# Altium schematic sheet Overcurrent.SchDoc
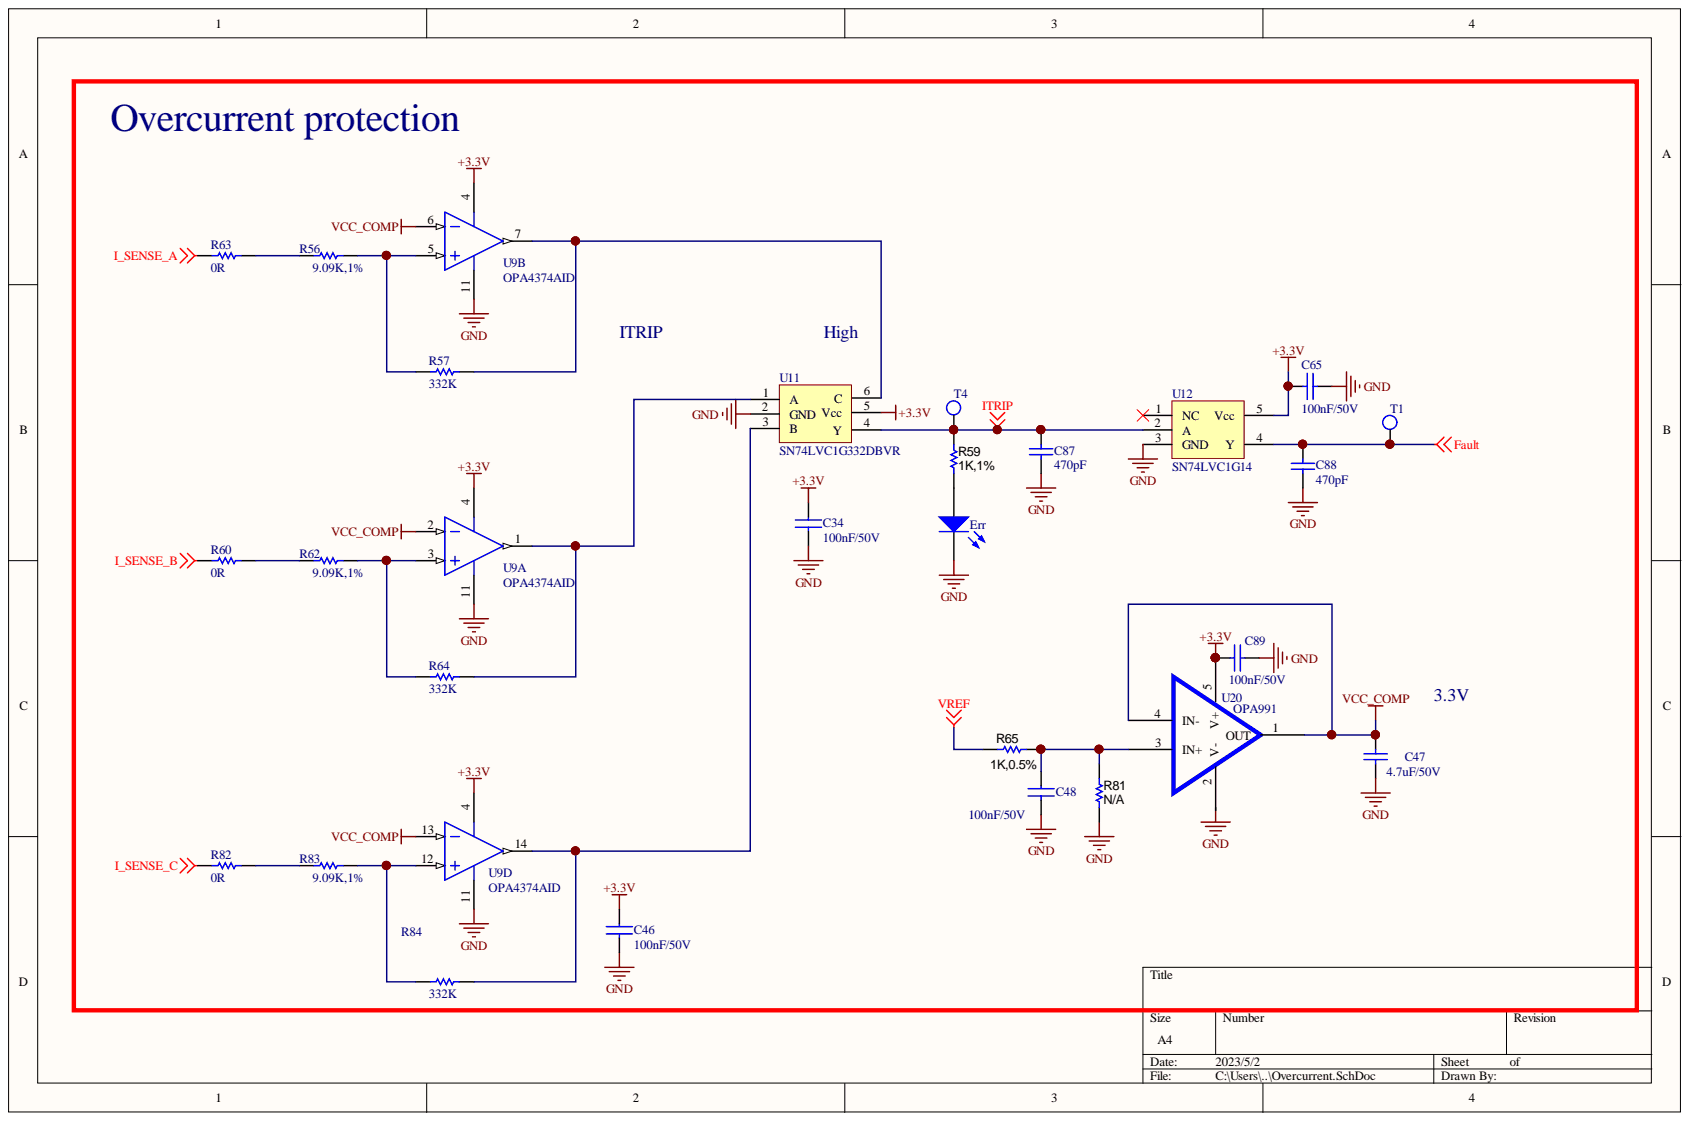
[diagram p0: Overcurrent.SchDoc]

## Parts
C34  100nF/50V  [0603]
C46  100nF/50V  [0603]
C47  4.7uF/50V  [1206]
C48  100nF/50V  [0603]
C65  100nF/50V  [0603]
C87  470pF  [0603]
C88  470pF  [0603]
C89  100nF/50V  [0603]
Err  RED  [0805_DIODE]  (value not drawn)
R56  9.09K,1%  [0603]
R57  332K  [0603]
R59  1K,1%  [0603]
R60  0R  [0603]
R62  9.09K,1%  [0603]
R63  0R  [0603]
R64  332K  [0603]
R65  1K,0.5%  [0603]
R81  N/A  [0603]
R82  0R  [0603]
R83  9.09K,1%  [0603]
R84  332K  [0603]
T1  Test_Ring  [1]  (value not drawn)
T4  Test_Ring  [1]  (value not drawn)
U9  OPA4374AID  [SOIC14_3.90MM]  (parts A,B,D)
U11  SN74LVC1G332DBVR  [SOT-236]
U12  SN74LVC1G14  [SOT-235]
U20  OPA991  [SOT-235]

## Nets
+3.3V: C34.1 C46.1 C65.2 C89.2 U9.4 U11.5(Vcc) U12.5(Vcc) U20.5(V+)
Fault: C88.1 T1.1 U12.4(Y)
GND: C34.2 C46.2 C47.1 C48.2 C65.1 C87.2 C88.2 C89.1 Err.2 R81.1 U9.11 U11.2(GND) U12.3(GND)
I_SENSE_A: R63.1
I_SENSE_B: R60.1
I_SENSE_C: R82.1
ITRIP: C87.1 R59.2 T4.1 U11.4(Y) U12.2(A)
VCC_COMP: C47.2 U9.2 U9.6 U9.13 U20.1(OUT) U20.4(IN-)
VREF: R65.1
NetC48_1: C48.1 R65.2 R81.2 U20.3(IN+)
NetErr_1: Err.1 R59.1
NetR56_1: R56.1 R63.2
NetR56_2: R56.2 R57.1 U9.5
NetR57_2: R57.2 U9.7 U11.6(C)
NetR60_2: R60.2 R62.1
NetR62_2: R62.2 R64.1 U9.3
NetR64_2: R64.2 U9.1 U11.1(A)
NetR82_2: R82.2 R83.1
NetR83_2: R83.2 R84.1 U9.12
NetR84_2: R84.2 U9.14 U11.3(B)

Not connected: U12 1(NC); U20 2(V-)

## Library data of the parts
C34 C46 C48 = Component-CAP: User Parameter 1: foot; Component Kind: Standard; Library Name: AltiumDesigner_Lib-tony.SchLib
C47 C87 C88 = C1206: Component Kind: Standard; Library Name: useForA104.IntLib
C65 C89 = CAP: Capacitor; Component Kind: Standard
Err = LED 0805: Component Kind: Standard; Library Name: useForA104.IntLib
R56 R57 R60 R62-R64 R82-R84 = R1206: Component Kind: Standard; Library Name: useForA104.IntLib
R59 = RC0603FR-071KL: Manufacturer: Yageo America; CompType: Resistor; EIA_Code: E96; Power_W: 0.1; Res_ohm: 1000; TempCo: 200 ppm/C; Tolerance_%: 1; U/M: EA; Value: 1.00k; Value2: 0.1W; Value_Code: 1k00; VDC_V: 150; Component Kind: Standard; ComponentLink1Description: Datasheet link; ComponentLink1URL: http://www.yageo.com/pdf/Pu-RC0603_51_PbFree_L_2.pdf; LatestRevisionDate: 2014-05-20; Library Name: Resistor_0603.IntLib; MountType: SMT; PackageReference: 0603; PartNumber: RC0603FR-071KL; Published: 2008-06-26; RoHS: Y; Signal Integrity: Resistor; Simulation: Resistor; Supplier 1: Digi-Key; Supplier 2: Mouser; Supplier 3: Farnell; Supplier Part Number 1: 311-1.00KHRCT-ND; Supplier Part Number 2: 603-RC0603FR-071KL; Supplier Part Number 3: 9238484; SymbolVersion: 2009-05-29; Technology: Thick Film; Value1: 1%; Publisher: Texas Instruments
R65 = RC0603FR-071KL: RES, 1.00k ohm, 1%, 0.1W, 0603; Manufacturer: Yageo America; CompType: Resistor; EIA_Code: E96; Power_W: 0.1; Res_ohm: 1000; TempCo: 200 ppm/C; Tolerance_%: 1; U/M: EA; Value_Code: 1k00; VDC_V: 150; Component Kind: Standard; ComponentLink1Description: Datasheet link; ComponentLink1URL: http://www.yageo.com/pdf/Pu-RC0603_51_PbFree_L_2.pdf; LatestRevisionDate: 2014-05-20; Library Name: Resistor_0603.IntLib; MountType: SMT; PackageReference: 0603; PartNumber: RC0603FR-071KL; Published: 2008-06-26; RoHS: Y; Signal Integrity: Resistor; Simulation: Resistor; Supplier 1: Digi-Key; Supplier 2: Mouser; Supplier 3: Farnell; Supplier Part Number 1: 311-1.00KHRCT-ND; Supplier Part Number 2: 603-RC0603FR-071KL; Supplier Part Number 3: 9238484; SymbolVersion: 2009-05-29; Technology: Thick Film; Value: 1.00k; Value1: 1%; Value2: 0.1W; Publisher: Texas Instruments
R81 = RC0603FR-071KL: Manufacturer: Yageo America; CompType: Resistor; EIA_Code: E96; Power_W: 0.1; Res_ohm: 1000; TempCo: 200 ppm/C; Tolerance_%: 1; U/M: EA; Value: 1.00k; Value1: 1%; Value2: 0.1W; Value_Code: 1k00; VDC_V: 150; Component Kind: Standard; ComponentLink1Description: Datasheet link; ComponentLink1URL: http://www.yageo.com/pdf/Pu-RC0603_51_PbFree_L_2.pdf; LatestRevisionDate: 2014-05-20; Library Name: Resistor_0603.IntLib; MountType: SMT; PackageReference: 0603; PartNumber: RC0603FR-071KL; Published: 2008-06-26; RoHS: Y; Signal Integrity: Resistor; Simulation: Resistor; Supplier 1: Digi-Key; Supplier 2: Mouser; Supplier 3: Farnell; Supplier Part Number 1: 311-1.00KHRCT-ND; Supplier Part Number 2: 603-RC0603FR-071KL; Supplier Part Number 3: 9238484; SymbolVersion: 2009-05-29; Technology: Thick Film; Publisher: Texas Instruments
U9 = OPA4374AIPWT: Quad 6.5 MHz, 585 uA, Rail-to-Rail I/O CMOS Operational Amplifier, 2.3 to 5.5 V, -40 to 125 degC, 14-pin SOP (PW14), Green (RoHS & no Sb/Br); Manufacturer: Texas Instruments; CMRR(Min)(dB): 80; GBW(Typ)(MHz): 6.5; IIB(Max)(pA): 10; Iq per channel(Max)(mA): 0.75; Number of Channels: 4; Offset Drift(Typ)(uV/C): 3; Packing: SMALL T&R; Rating: Catalog; Slew Rate(Typ)(V/us): 5; Sub Family: High Output Current Amplifier (>=50mA), Low Input Bias Current Amp (<=10pA), Low Supply Voltage Amplifier (<=2.7V), Precision Amplifier; Total Supply Voltage(Max)(+5V is 5, +/-5V is 10): 5.5; Total Supply Voltage(Min)(+5V is 5, +/-5V is 10): 2.3; Code_JEDEC: MO-153; Component Kind: Standard; ComponentLink1Description: Manufacturer URL; ComponentLink1URL: http://www.ti.com/; ComponentLink2Description: Datasheet; ComponentLink2URL: http://www.ti.com/lit/ds/symlink/opa4374.pdf; ComponentLink3Description: Package Specification; ComponentLink3URL: http://www.ti.com/litv/pdf/mpds360; DatasheetVersion: SBOS279E; Eco-Plan: Green (RoHS & no Sb/Br); Mounting Technology: Surface Mount; PackageDescription: 14-Pin Plastic Small Outline, Body 5 x 4.4 mm, Pitch 0.65 mm; PackageReference: PW14; PackageVersion: 4040064-3/G, 02/2011; PartNumber: OPA4374AIPWT; RoHs: Y; Supplier 1: Digi-Key; Supplier 2: Digi-Key; Supplier 3: Digi-Key; Supplier 4: Newark; Supplier 5: Mouser; Supplier Part Number 1: 296-16811-2-ND; Supplier Part Number 2: 296-16811-1-ND; Supplier Part Number 3: 296-16811-6-ND; Supplier Part Number 4: 90H2251; Supplier Part Number 5: 595-OPA4374AIPWT; Vio (25C)(Max)(mV): 5; Library Name: TI Amplifiers and Linear Operational Amplifier Precision Amplifier Part Two.IntLib
U11 = TI-SN74LVC1G332: Component Kind: Standard; Library Name: AltiumDesigner_Lib-syc.SchLib
U12 = TI-SN74LVC1G14: Single Schmitt-Trigger Inverter; Component Kind: Standard; Library Name: AltiumDesigner_Lib-syc.SchLib
U20 = TI-OPA991: Component Kind: Standard; Library Name: AltiumDesigner_Lib-syc.SchLib
T1 T4 = Test_Ring: Component Kind: Standard; Library Name: AltiumDesigner_Lib-syc.SchLib
